# Altium schematic sheet 5_power.SchDoc(5_power)
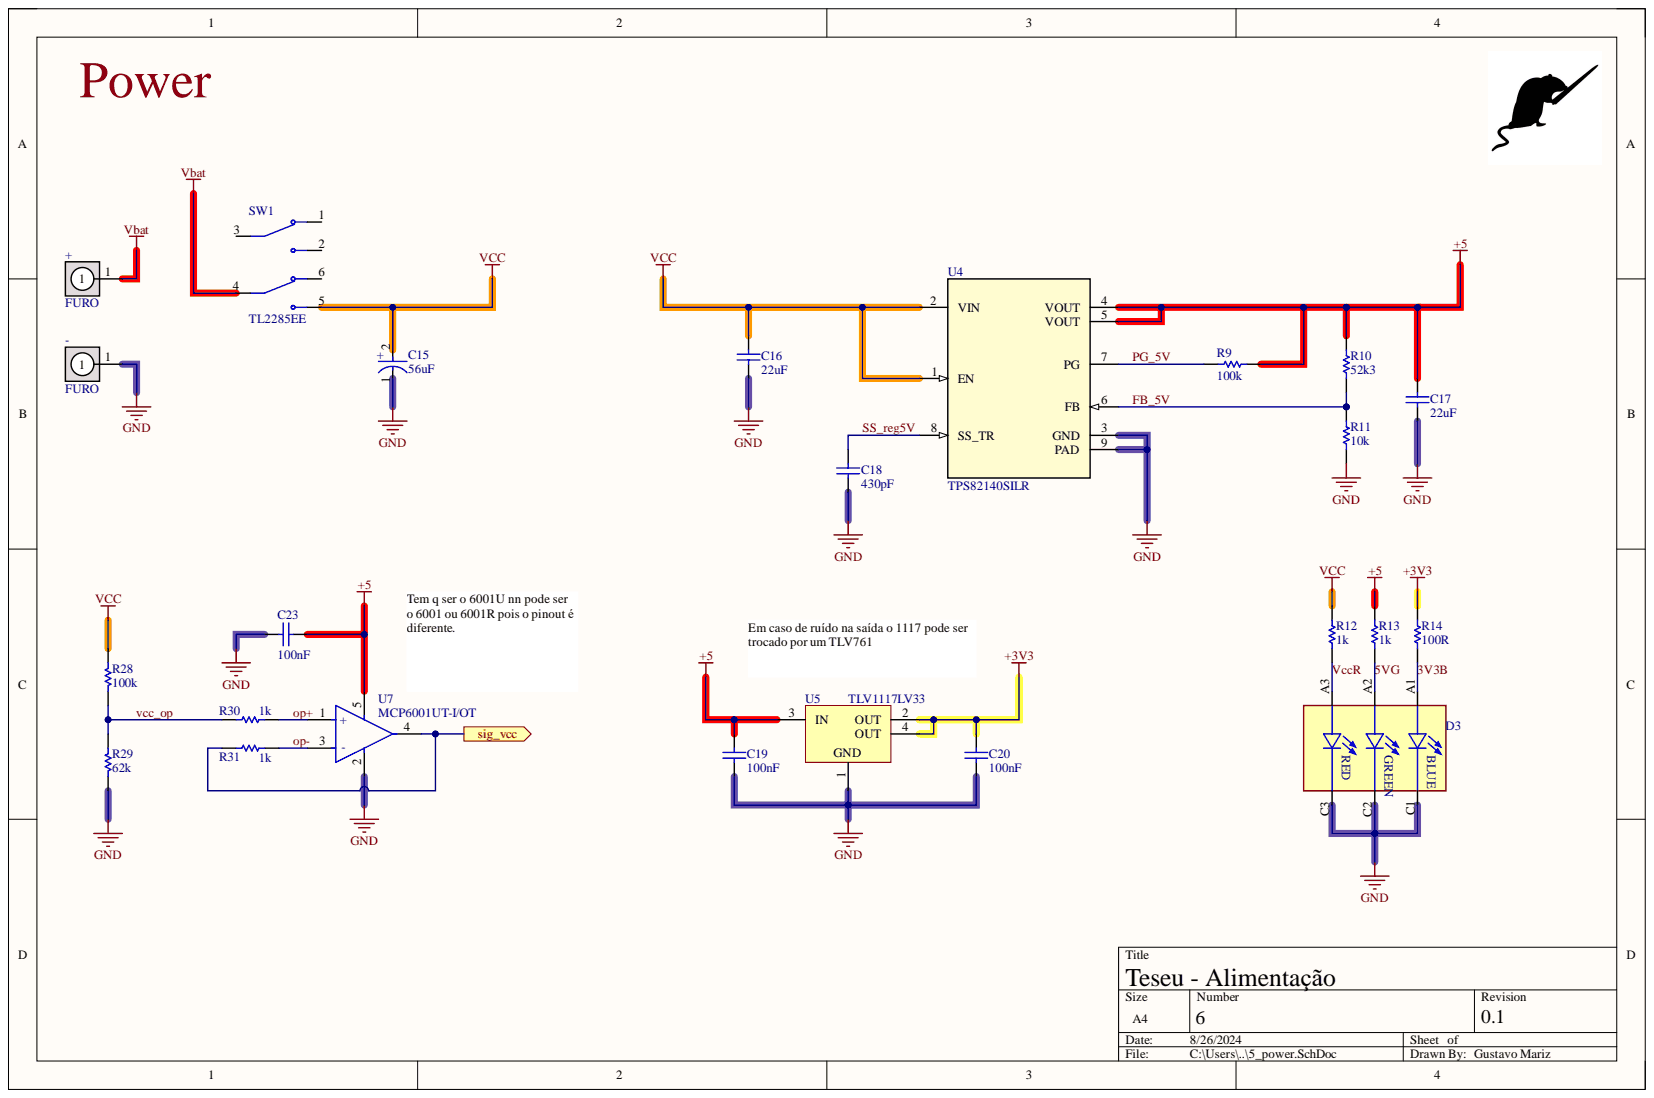
[diagram p0: 5_power.SchDoc]

## Parts
+  FURO  [FURO_2,5MM]
-  FURO  [FURO_2,5MM]
C15  56uF  [FP-PSG-F05-MFG]
C16  Valor: 22uF  [CAP 0805]  (hidden comment: CAP)
C17  Valor: 22uF  [CAP 0805]  (hidden comment: CAP)
C18  Valor: 430pF  [0603]  (hidden comment: CAP)
C19  Valor: 100nF  [0603]  (hidden comment: CAP)
C20  Valor: 100nF  [0603]  (hidden comment: CAP)
C23  Valor: 100nF  [0603]  (hidden comment: CAP)
D3  KRTBLSLPS1.32-VUVX-EQ+BTBV-D8+TWTZ-L1-B  [LED_KRTBLSLPS1.32-VUVX-EQ+BTBV-D8+TWTZ-L1-B]  (value not drawn)
R9  value 100k  [RES 0603]  (hidden comment: RESISTOR)
R10  value 52k3  [RES 0603]  (hidden comment: RESISTOR)
R11  value 10k  [RES 0603]  (hidden comment: RESISTOR)
R12  value 1k  [RES 0603]  (hidden comment: RESISTOR)
R13  value 1k  [RES 0603]  (hidden comment: RESISTOR)
R14  value 100R  [RES 0603]  (hidden comment: RESISTOR)
R28  value 100k  [RES 0603]  (hidden comment: RESISTOR)
R29  value 62k  [RES 0603]  (hidden comment: RESISTOR)
R30  value 1k  [RES 0603]  (hidden comment: RESISTOR)
R31  value 1k  [RES 0603]  (hidden comment: RESISTOR)
SW1  TL2285EE  [SW_TL2285EE]
U4  TPS82140SILR  [SIL0008D_SMD]
U5  TLV1117LV33  [DCY0004A_N]
U7  MCP6001UT-I/OT  [FP-C04-091-OT-IPC_C]

## Ports
sig_vcc

## Nets
GND: -.1 C15.1 C16.1 C17.1 C18.1 C19.1 C20.1 C23.1 D3.C1 D3.C2 D3.C3 R11.1 R29.1 U4.3(GND) U4.9(PAD) U5.1 U7.2
Vbat: +.1 SW1.4
VCC: C15.2 C16.2 R12.2 R28.2 SW1.5 U4.1(EN) U4.2(VIN)
3V3B: C20.2 D3.A1 R14.1 R14.2 U5.2(OUT) U5.4(OUT)
5VG: C17.2 C19.2 C23.2 D3.A2 R9.1 R10.2 R13.1 R13.2 U4.4(VOUT) U4.5(VOUT) U5.3(IN) U7.5
FB_5V: R10.1 R11.2 U4.6(FB)
op+: R30.2 U7.1(+)
op-: R31.2 U7.3(-)
PG_5V: R9.2 U4.7(PG)
sig_vcc: R31.1 U7.4 ; port sig_vcc
SS_reg5V: C18.2 U4.8(SS_TR)
vcc_op: R28.1 R29.2 R30.1
VccR: D3.A3 R12.1

Not connected: SW1 1 2 3 6

## Library data of the parts
+ - = FURO NA PLACA: Criado por: Luigi Eduardo; Pertence a: Trincabotz CEFET-MG; Component Kind: Standard; Criado em: 26/06/2012; Description: Furo; Library Name: Biblioteca Trincabotz (1).IntLib
C15 = CMP-33769-000002-1: CAP ALUM POLY 56UF 20% 25V T/H  APSG250ELL560MF05S  https://www.mouser.com/ProductDetail/Chemi-Con/APSG250ELL560MF05S?qs=nxZbHzLpdvcfhTNzSmDv4w%3D%3D; Manufacturer: United Chemi-Con; Dissipation Factor: 12%; Esr: 30mΩ; Leakage Current: 500uA; Life: 15000Hours; Material: Polymer; Ripple Current: 2600mA; Automotive: No; Capacitance: 56uF; Category: Cap; Component Kind: Standard; ComponentLink2Description: Datasheet; ComponentLink2URL: http://www.chemi-con.co.jp/cgi-bin/CAT_DB/SEARCH/cat_db_al.cgi?e=e&j=p&pdfname=psg; Device Class L1: Passive Components; Device Class L2: Capacitors; Device Class L3: Polymer Capacitors; Digikey Description: CAP ALUM POLY 56UF 20% 25V T/H; Height: 6mm; Lead Free: Yes; Library Name: Altium Content Vault; Manufacturer Part Number: APSG250ELL560MF05S; Octopart: https://octopart.com/apsg250ell560mf05s-united+chemi-con-70515924; Package: PSG-F05; RoHS: TRUE; Temperature: -55°C to +105°C; Temperature Range High: +105°C; Temperature Range Low: -55°C; Tolerance: 20%; Value: 56uF; Voltage Rating: 25V
C16-C20 C23 = CAP. CERÂMICO: Capacitor; Criador por: Luigi Eduardo; Pertence a: Trincabotz; Component Kind: Standard; Criado em: 26/06/2012; Library Name: Biblioteca Trincabotz (1).IntLib
D3 = KRTBLSLPS1.32-VUVX-EQ+BTBV-D8+TWTZ-L1-B: Red, Green, Blue (RGB) 621nm Red, 532nm Green, 467nm Blue LED Indication - Discrete 2.05V Red, 2.65V Green, 2.85V Blue 6-SMD, J-Lead; MF: OSRAM Opto Semiconductors Inc.; Availability: In Stock; Check_prices: https://www.snapeda.com/parts/KRTBLSLPS1.32-VUVX-EQ+BTBV-D8+TWTZ-L1-B/OSRAM+Opto+Semiconductors+Inc./view-part/?ref=eda; Component Kind: Standard; Library Name: KRTBLSLPS1.32-VUVX-EQ_BTBV-D8_TWTZ-L1-B.IntLib; MP: KRTBLSLPS1.32-VUVX-EQ+BTBV-D8+TWTZ-L1-B; Package: SMD-6 OSRAM Opto Semiconductors Inc.; Price: None; Purchase-URL: https://pricing.snapeda.com/search/part/KRTBLSLPS1.32-VUVX-EQ+BTBV-D8+TWTZ-L1-B/?ref=eda; SnapEDA_Link: https://www.snapeda.com/parts/KRTBLSLPS1.32-VUVX-EQ+BTBV-D8+TWTZ-L1-B/OSRAM+Opto+Semiconductors+Inc./view-part/?ref=snap
U4 = TPS82140SILR: No Description Available; Mfr_Name: Texas Instruments; Component Kind: Standard; Library Name: TPS82140SILR.IntLib; RefDes: RefDes; TYPE: TYPE
U5 = CMP-0395-00503-2: Single Output LDO, 1 A, Fixed 3.3 V Output, 2 to 5.5 V Input, 4-pin SOT-223 (DCY), -40 to 125 degC, Green (RoHS & no Sb/Br); Manufacturer: Texas Instruments; PartNumber: TLV1117LV33DCYR; Accuracy(%): 2; Fixed Output Options(V): 3.3; Iout(Max)(A): 1; Iq(Typ)(mA): 0.05; SubFamily: Single Channel LDO; Vdo(Typ)(mV): 1200; Vin(Max)(V): 5.5; Code_JEDEC: TO-261; Component Kind: Standard; ComponentLink1Description: Manufacturer URL; ComponentLink1URL: http://www.ti.com/; ComponentLink2Description: Datasheet; ComponentLink2URL: http://www.ti.com/general/docs/lit/getliterature.tsp?genericPartNumber=TLV1117LV33&fileType=pdf; ComponentLink3Description: Package Specification; ComponentLink3URL: http://www.ti.com/litv/pdf/mpds094a; DatasheetVersion: SBVS160A; Eco-Plan: Green (RoHS & no Sb/Br); Library Name: Altium Content Vault; Mounting Technology: Surface Mount; PackageDescription: 4-Pin Plastic Small Outline, Body 3.5 x 6.5 mm, Pitch 2.3 mm; PackageReference: DCY0004A; PackageVersion: 4202506/B; Vin(Min)(V): 2; Vout Adj(Max)(V): 3.3; Vout Adj(Min)(V): 3.3
U7 = CMP-0187-00471-3: IC OPAMP GP 1 CIRCUIT SOT23-5; Bandwidth: 1MHz; Common Mode Rejection Ratio: 60dB; Input Bias Current: 1pA; Input Offset Voltage (Vos): 4.5mV; Max Frequency: 1kHz; Max Supply Voltage: 6V; Min Supply Voltage: 1.8V; Nominal Supply Current: 100uA; Number of Amplifiers: 1; Operating Supply Voltage: 5.5V; Output Current: 23mA; Power Supply Rejection Ratio (PSRR): 86dB; Slew Rate: 0.6V/µs; Voltage Gain: 112dB; Case/Package: SOT-23; Component Kind: Standard; Gain Bandwidth Product: 1MHz; Height: 1.3mm; Lead Free: Lead Free; Length: 3.1mm; Library Name: Altium Content Vault; Max Operating Temperature: 85°C; Min Operating Temperature: -40°C; Mount: Surface Mount; Number of Channels: 1; Number of Circuits: 1; Number of Elements: 1; Number of Pins: 5; Package Quantity: 3000; Packaging: Tape and Reel; Radiation Hardening: No; REACH SVHC: No SVHC; Unity Gain Bandwidth Product: 1MHz; Width: 1.8mm
R9-R14 R28-R31 = RESISTOR: Component Kind: Standard; Description: Resistor; Library Name: Biblioteca Trincabotz (1).IntLib
SW1 = TL2285EE: Availability: Unavailable; Component Kind: Standard; Library Name: TL2285EE.IntLib; MP: TL2285EE; Package: None; Price: None
